# Altium schematic sheet General Overview.SchDoc("General Overview")
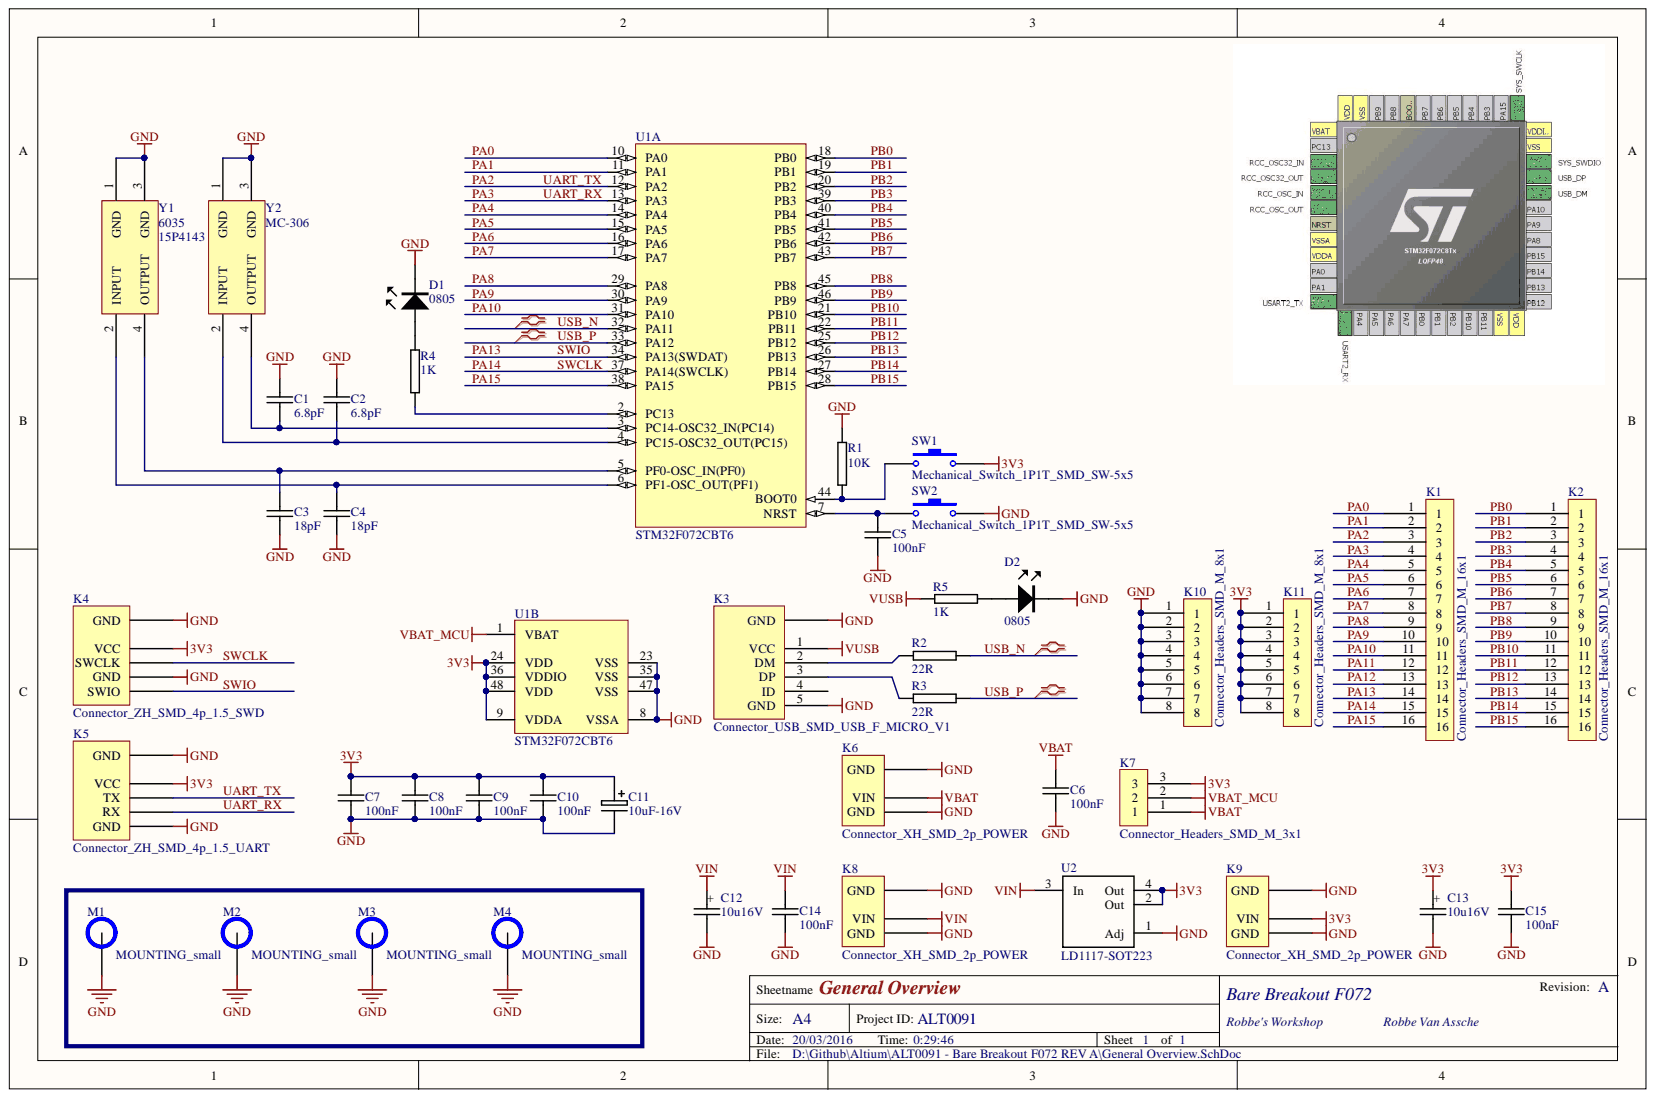
[diagram p0: General Overview.SchDoc]

## Parts
C1  6.8pF  [C0402]
C2  6.8pF  [C0402]
C3  18pF  [C0402]
C4  18pF  [C0402]
C5  100nF  [C0402]
C6  100nF  [C0402]
C7  100nF  [C0402]
C8  100nF  [C0402]
C9  100nF  [C0402]
C10  100nF  [C0402]
C11  10uF-16V  [PANACAP-b]
C12  10u16V  [1206C+_TANTAL-10u16V]
C13  10u16V  [1206C+_TANTAL-10u16V]
C14  100nF  [C0402]
C15  100nF  [C0402]
D1  0805  [0805LED]
D2  0805  [0805LED]
K1  Connector_Headers_SMD_M_16x1  [Header_Male_2.54 16x1_COMBO]
K2  Connector_Headers_SMD_M_16x1  [Header_Male_2.54 16x1_COMBO]
K3  Connector_USB_SMD_USB_F_MICRO_V1  [USB_F_Micro_V1]
K4  Connector_ZH_SMD_4p_1.5_SWD  [ZH_SMD_4p_1.5]
K5  Connector_ZH_SMD_4p_1.5_UART  [ZH_SMD_4p_1.5]
K6  Connector_XH_SMD_2p_POWER  [2.54_2p_THT+SMD]
K7  Connector_Headers_SMD_M_3x1  [Header_Male_2.54 3x1_COMBO]
K8  Connector_XH_SMD_2p_POWER  [2.54_2p_THT+SMD]
K9  Connector_XH_SMD_2p_POWER  [2.54_2p_THT+SMD]
K10  Connector_Headers_SMD_M_8x1  [Header_Male_2.54 8x1_COMBO]
K11  Connector_Headers_SMD_M_8x1  [Header_Male_2.54 8x1_COMBO]
M1  MOUNTING_small  [MOUNTING M3 small]
M2  MOUNTING_small  [MOUNTING M3 small]
M3  MOUNTING_small  [MOUNTING M3 small]
M4  MOUNTING_small  [MOUNTING M3 small]
R1  10K  [R0402]
R2  22R  [R0402]
R3  22R  [R0402]
R4  1K  [R0402]
R5  1K  [R0402]
SW1  Mechanical_Switch_1P1T_SMD_SW-5x5  [1P1T_SW_5x5]
SW2  Mechanical_Switch_1P1T_SMD_SW-5x5  [1P1T_SW_5x5]
U1  STM32F072CBT6  [STM-LQFP48_N]  (parts A,B)
U2  LD1117-SOT223  [SOT223]
Y1  6035  Supplier Part Number 1: 15P4143  [XTAL 6035]
Y2  MC-306  [XTAL MC-306]

## Nets
3V3: C7.1 C8.1 C9.1 C10.1 C11.+ C13.1 C15.1 K4.1(VCC) K5.1(VCC) K7.3 K9.1 K11.1 K11.2 K11.3 K11.4 K11.5 K11.6 K11.7 K11.8 SW1.2 U1.9(VDDA) U1.24(VDD) U1.36(VDDIO) U1.48(VDD) U2.2(Out) U2.4(Out)
GND: C1.1 C2.1 C3.2 C4.2 C5.2 C6.2 C7.2 C8.2 C9.2 C10.2 C11.- C12.2 C13.2 C14.2 C15.2 D1.K D2.K K3.0(GND) K3.5(GND) K4.0(GND) K4.3(GND) K5.0(GND) K5.4(GND) K6.0 K6.2 K8.0 K8.2 K9.0 K9.2 K10.1 K10.2 K10.3 K10.4 K10.5 K10.6 K10.7 K10.8 M1.1 M2.1 M3.1 M4.1 R1.1 SW2.2 U1.8(VSSA) U1.23(VSS) U1.35(VSS) U1.47(VSS) U2.1(Adj) Y1.1(GND) Y1.3(GND) Y2.1(GND) Y2.3(GND)
VBAT: C6.1 K6.1 K7.1
VBAT_MCU: K7.2 U1.1(VBAT)
VIN: C12.1 C14.1 K8.1 U2.3(In)
VUSB: K3.1(VCC) R5.1
PA0: K1.1 U1.10(PA0)
PA1: K1.2 U1.11(PA1)
PA2: K1.3 K5.2(TX) U1.12(PA2)
PA3: K1.4 K5.3(RX) U1.13(PA3)
PA4: K1.5 U1.14(PA4)
PA5: K1.6 U1.15(PA5)
PA6: K1.7 U1.16(PA6)
PA7: K1.8 U1.17(PA7)
PA8: K1.9 U1.29(PA8)
PA9: K1.10 U1.30(PA9)
PA10: K1.11 U1.31(PA10)
PA11: K1.12
PA12: K1.13
PA13: K1.14 K4.4(SWIO) U1.34(PA13(SWDAT))
PA14: K1.15 K4.2(SWCLK) U1.37(PA14(SWCLK))
PA15: K1.16 U1.38(PA15)
PB0: K2.1 U1.18(PB0)
PB1: K2.2 U1.19(PB1)
PB2: K2.3 U1.20(PB2)
PB3: K2.4 U1.39(PB3)
PB4: K2.5 U1.40(PB4)
PB5: K2.6 U1.41(PB5)
PB6: K2.7 U1.42(PB6)
PB7: K2.8 U1.43(PB7)
PB8: K2.9 U1.45(PB8)
PB9: K2.10 U1.46(PB9)
PB10: K2.11 U1.21(PB10)
PB11: K2.12 U1.22(PB11)
PB12: K2.13 U1.25(PB12)
PB13: K2.14 U1.26(PB13)
PB14: K2.15 U1.27(PB14)
PB15: K2.16 U1.28(PB15)
USB_N: R2.2 U1.32(PA11)
USB_P: R3.2 U1.33(PA12)
NetC1_2: C1.2 U1.3(PC14-OSC32_IN(PC14)) Y2.4(OUTPUT)
NetC2_2: C2.2 U1.4(PC15-OSC32_OUT(PC15)) Y2.2(INPUT)
NetC3_1: C3.1 U1.5(PF0-OSC_IN(PF0)) Y1.4(OUTPUT)
NetC4_1: C4.1 U1.6(PF1-OSC_OUT(PF1)) Y1.2(INPUT)
NetC5_1: C5.1 SW2.1 U1.7(NRST)
NetD1_A: D1.A R4.1
NetD2_A: D2.A R5.2
NetK3_2: K3.2(DM) R2.1
NetK3_3: K3.3(DP) R3.1
NetR1_2: R1.2 SW1.1 U1.44(BOOT0)
NetR4_2: R4.2 U1.2(PC13)

Not connected: K3 4(ID)

## Library data of the parts
C1-C10 C14 C15 = Passives_Capacitors_0402_TBD: CAPACITOR 0402 CERAMIC; Component Kind: Standard; Library Name: RVA_Passives_Capacitors_0402.SchLib
C11 = Passives_Capacitors_Elco_SMD_PANACAP-10UF-16V-FK: GENERIC CAPACITOR POLARISED; Component Kind: Standard; Supplier 1: Digi-Key; Supplier Part Number 1: PCE3782TR-ND; Library Name: RVA_Passives_Capacitors_Elco_SMD.SchLib
C12 C13 = Passives_Capacitors_Tantalum_1206C-TANTAL SIZE A-10u16V: Component Kind: Standard; Library Name: RVA_Passives_Capacitors_Tantalum.SchLib
D1 D2 = LED_SMD_0805: Component Kind: Standard; Library Name: RVA_LED_SMD_0805.SchLib
K1 K2 = Connector_Headers_SMD_M_16x1: SMD Header 16x1; Component Kind: Standard; Library Name: RVA_Connector_Headers_SMD.SchLib
K3 = Connector_USB_SMD_USB_F_MICRO_V1: MICRO USB B FEMALE; Component Kind: Standard; Library Name: RVA_Connector_USB_SMD.SchLib
K7 = Connector_Headers_SMD_M_3x1: SMD Header 3x1; Component Kind: Standard; Library Name: RVA_Connector_Headers_SMD.SchLib
K10 K11 = Connector_Headers_SMD_M_8x1: SMD Header 8x1; Component Kind: Standard; Library Name: RVA_Connector_Headers_SMD.SchLib
M1-M4 = MOUNTING_small: MOUNTING HOLE - NON INSTALLABLE; Component Kind: Standard; Library Name: RVA_Mechanical_THT.SchLib
R1-R5 = Passives_Resistors_0402_TBD: RESISTOR 0402 VALUE TO BE DETERMINED; Component Kind: Standard; Library Name: RVA_Passives_Resistors_0402.SchLib
SW1 SW2 = Mechanical_Switch_1P1T_SMD_SW-5x5: Switch 5x5; Component Kind: Standard; Library Name: RVA_Mechanical_Switch_1P1T_SMD.SchLib
U1 = Microcontrollers_ST_ARM_STM32F0_STM32F072CBT6: PartNumber: STM32F051C8T6; Core: ARM Cortex-M0; FLASH Size (Prog) (kB): 64; I/Os (High Current): 39; Internal RAM Size (kB): 8; Operating Frequency(F) (Processor speed) (MHz): 48; Packing: Tray; Supply Voltage(Vcc) min (V): 2; Component Kind: Standard; ComponentLink1Description: Manufacturer URL; ComponentLink1URL: http://www.st.com/web/en/home.html; ComponentLink2Description: Datasheet; ComponentLink2URL: http://www.st.com/st-web-ui/static/active/en/resource/technical/document/datasheet/DM00039193.pdf; ComponentLink3Description: Package Specification; ComponentLink3URL: http://www.st.com/st-web-ui/static/active/en/resource/technical/document/datasheet/DM00058181.pdf; DatasheetVersion: Rev. 3; Mounting Technology: Surface Mount; PackageDescription: 48-Pin Low Profile Quad Flat Package, 7 x 7 mm Body, 0.5 mm Pitch; PackageReference: LQFP48; PackageVersion: Rev. 6, 06/2013; Supplier 1: Digi-Key; Supplier 2: Farnell; Supplier 3: Newark; Supplier 4: Newark; Supplier 5: Mouser; Supplier Part Number 1: 497-12893-ND; Supplier Part Number 2: 2115065; Supplier Part Number 3: 05W5802; Supplier Part Number 4: 90T0636; Supplier Part Number 5: 511-STM32F051C8T6; Library Name: RVA_Microcontrollers_ST_ARM_STM32F0.SchLib
Y1 = 6035 Quartz: Component Kind: Standard; Supplier 1: Newark; Library Name: RVA_Reference_Crystal Oscillator_SMD.SchLib
Y2 = 32k Quartz: Component Kind: Standard; Library Name: RVA_Reference_Crystal Oscillator_SMD.SchLib
K4 = Connector_ZH_SMD_4p_1.5_SWD: Component Kind: Standard; Library Name: RVA_Connector_ZH_SMD.SchLib
K5 = Connector_ZH_SMD_4p_1.5_UART: Component Kind: Standard; Library Name: RVA_Connector_ZH_SMD.SchLib
K6 K8 K9 = Connector_XH_SMD_2p_POWER: Component Kind: Standard; Library Name: RVA_Connector_XH_SMD.SchLib
U2 = LD1117-SOT223: Component Kind: Standard; Library Name: RVA_PSU_LDO_SMD.SchLib
